# Altium schematic sheet EvvGC v1.3.SchDoc
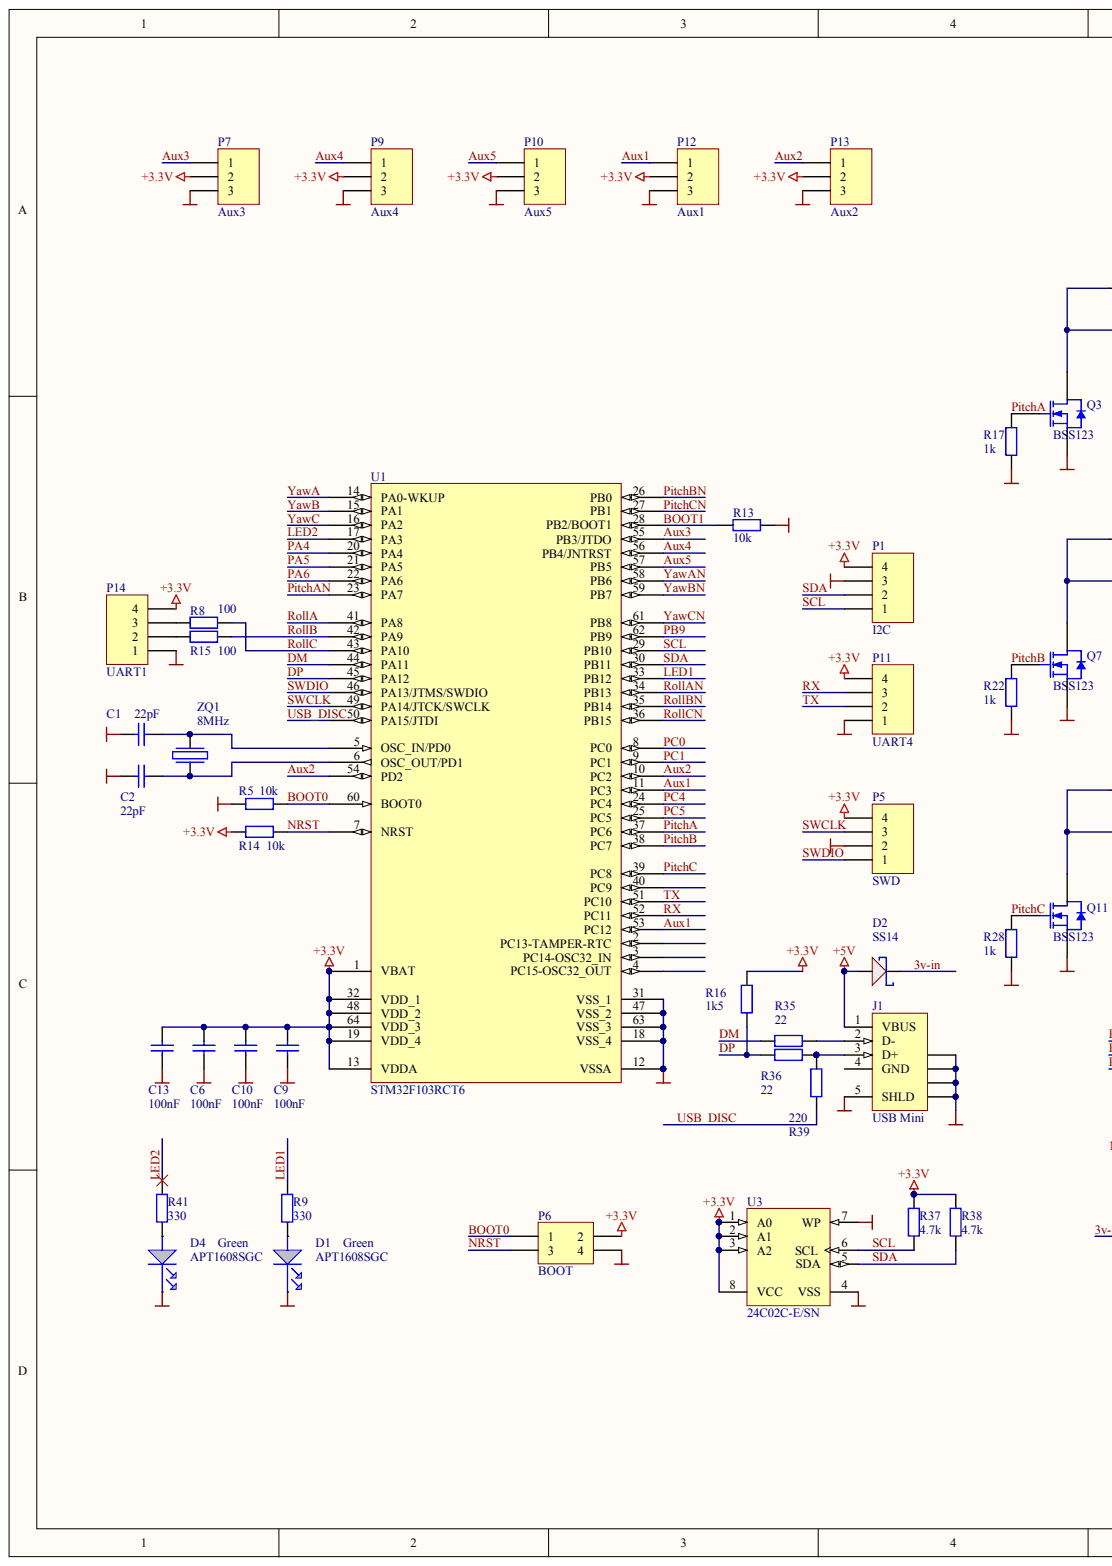
[diagram p0: EvvGC v1.3.SchDoc, left]
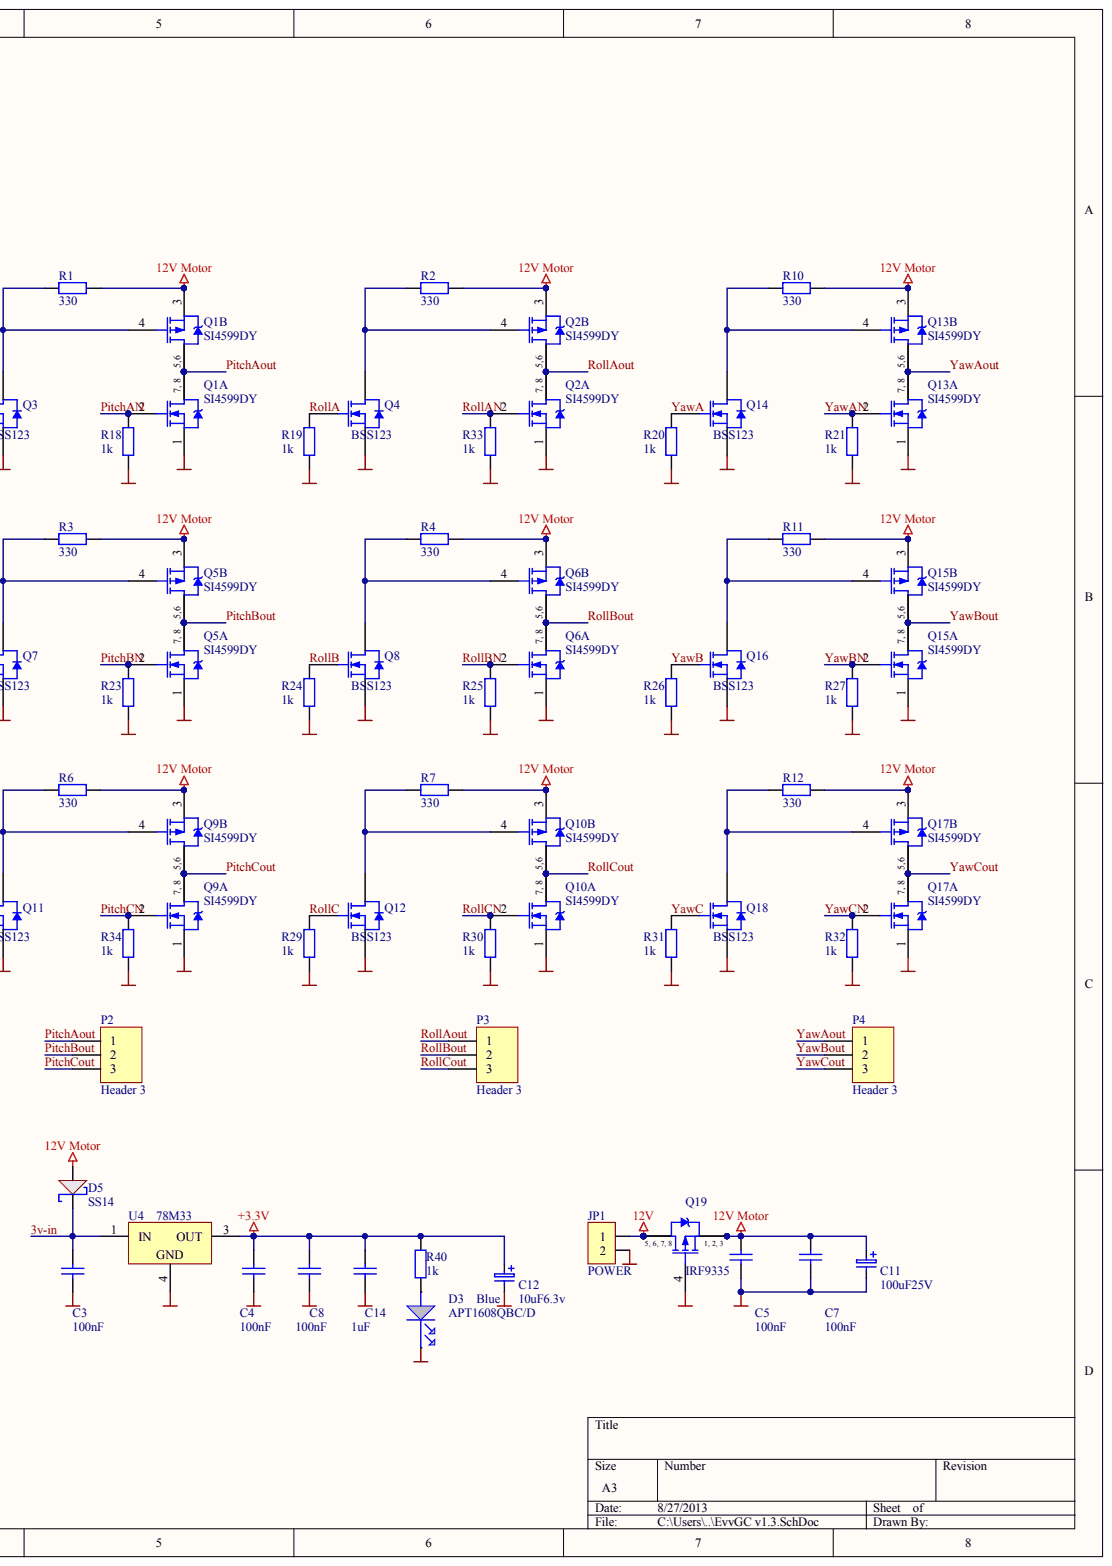
[diagram p1: EvvGC v1.3.SchDoc, right]

## Parts
C1  22pF
C2  22pF
C3  100nF
C4  100nF
C5  100nF
C6  100nF
C7  100nF
C8  100nF
C9  100nF
C10  100nF
C11  100uF25V
C12  10uF6.3v
C13  100nF
C14  1uF
D1  APT1608SGC  value Green
D2  SS14
D3  APT1608QBC/D  value Blue
D4  APT1608SGC  value Green
D5  SS14
J1  USB Mini
JP1  POWER
P1  I2C
P2  Header 3
P3  Header 3
P4  Header 3
P5  SWD
P6  BOOT
P7  Aux3
P9  Aux4
P10  Aux5
P11  UART4
P12  Aux1
P13  Aux2
P14  UART1
Q1  SI4599DY  (parts A,B)
Q2  SI4599DY  (parts A,B)
Q3  BSS123
Q4  BSS123
Q5  SI4599DY  (parts A,B)
Q6  SI4599DY  (parts A,B)
Q7  BSS123
Q8  BSS123
Q9  SI4599DY  (parts A,B)
Q10  SI4599DY  (parts A,B)
Q11  BSS123
Q12  BSS123
Q13  SI4599DY  (parts A,B)
Q14  BSS123
Q15  SI4599DY  (parts A,B)
Q16  BSS123
Q17  SI4599DY  (parts A,B)
Q18  BSS123
Q19  IRF9335
R1  330
R2  330
R3  330
R4  330
R5  10k
R6  330
R7  330
R8  100
R9  330
R10  330
R11  330
R12  330
R13  10k
R14  10k
R15  100
R16  1k5
R17  1k
R18  1k
R19  1k
R20  1k
R21  1k
R22  1k
R23  1k
R24  1k
R25  1k
R26  1k
R27  1k
R28  1k
R29  1k
R30  1k
R31  1k
R32  1k
R33  1k
R34  1k
R35  22
R36  22
R37  4.7k
R38  4.7k
R39  220
R40  1k
R41  330
U1  STM32F103RCT6
U3  24C02C-E/SN
U4  78M33
ZQ1  8MHz

## Nets
12V: JP1.1 Q19.5 Q19.6 Q19.7 Q19.8
12V MOTOR: C5.1 C7.1 C11.1 D5.A Q1.3 Q2.3 Q5.3 Q6.3 Q9.3 Q10.3 Q13.3 Q15.3 Q17.3 Q19.1 Q19.2 Q19.3 R1.2 R2.2 R3.2 R4.2 R6.2 R7.2 R10.2 R11.2 R12.2
+3.3V: C4.1 C6.1 C8.1 C9.1 C10.1 C12.1 C13.1 C14.1 P1.4 P5.4 P6.2 P7.2 P9.2 P10.2 P11.4 P12.2 P13.2 P14.4 R14.2 R16.1 R37.2 R38.2 R40.1 U1.1(VBAT) U1.13(VDDA) U1.19(VDD_4) U1.32(VDD_1) U1.48(VDD_2) U1.64(VDD_3) U3.1(A0) U3.2(A1) U3.3(A2) U3.8(VCC) U4.3
+5V: D2.A J1.1(VBUS)
GND: C1.2 C2.2 C3.2 C4.2 C5.2 C6.2 C7.2 C8.2 C9.2 C10.2 C11.2 C12.2 C13.2 C14.2 D1.K D3.K D4.K J1.5(SHLD) J1.6 J1.7 J1.8 J1.9 JP1.2 P1.3 P5.2 P6.4 P7.3 P9.3 P10.3 P11.1 P12.3 P13.3 P14.1 Q1.1 Q2.1 Q3.2 Q4.2 Q5.1 Q6.1 Q7.2 Q8.2 Q9.1 Q10.1 Q11.2 Q12.2 Q13.1 Q14.2 Q15.1 Q16.2 Q17.1 Q18.2 Q19.4 R5.2 R13.1 R17.1 R18.1 R19.1 R20.1 R21.1 R22.1 R23.1 R24.1 R25.1 R26.1 R27.1 R28.1 R29.1 R30.1 R31.1 R32.1 R33.1 R34.1 U1.12(VSSA) U1.18(VSS_4) U1.31(VSS_1) U1.47(VSS_2) U1.63(VSS_3) U3.4(VSS) U3.7(WP) U4.4
3V-IN: C3.1 D2.K D5.K U4.1
AUX1: P12.1 U1.11(PC3) U1.53(PC12)
AUX2: P13.1 U1.10(PC2) U1.54(PD2)
AUX3: P7.1 U1.55(PB3/JTDO)
AUX4: P9.1 U1.56(PB4/JNTRST)
AUX5: P10.1 U1.57(PB5)
BOOT0: P6.1 R5.1 U1.60(BOOT0)
BOOT1: R13.2 U1.28(PB2/BOOT1)
DM: R35.1 U1.44(PA11)
DP: R16.2 R36.1 U1.45(PA12)
LED1: R9.1 U1.33(PB12)
LED2: R41.1 U1.17(PA3)
NRST: P6.3 R14.1 U1.7(NRST)
PA4: U1.20(PA4)
PA5: U1.21(PA5)
PA6: U1.22(PA6)
PB9: U1.62(PB9)
PC0: U1.8(PC0)
PC1: U1.9(PC1)
PC4: U1.24(PC4)
PC5: U1.25(PC5)
PITCHA: Q3.1 R17.2 U1.37(PC6)
PITCHAN: Q1.2 R18.2 U1.23(PA7)
PITCHAOUT: P2.1 Q1.5 Q1.6 Q1.7 Q1.8
PITCHB: Q7.1 R22.2 U1.38(PC7)
PITCHBN: Q5.2 R23.2 U1.26(PB0)
PITCHBOUT: P2.2 Q5.5 Q5.6 Q5.7 Q5.8
PITCHC: Q11.1 R28.2 U1.39(PC8)
PITCHCN: Q9.2 R34.2 U1.27(PB1)
PITCHCOUT: P2.3 Q9.5 Q9.6 Q9.7 Q9.8
ROLLA: Q4.1 R19.2 U1.41(PA8)
ROLLAN: Q2.2 R33.2 U1.34(PB13)
ROLLAOUT: P3.1 Q2.5 Q2.6 Q2.7 Q2.8
ROLLB: Q8.1 R15.1 R24.2 U1.42(PA9)
ROLLBN: Q6.2 R25.2 U1.35(PB14)
ROLLBOUT: P3.2 Q6.5 Q6.6 Q6.7 Q6.8
ROLLC: Q12.1 R8.1 R29.2 U1.43(PA10)
ROLLCN: Q10.2 R30.2 U1.36(PB15)
ROLLCOUT: P3.3 Q10.5 Q10.6 Q10.7 Q10.8
RX: P11.3 U1.52(PC11)
SCL: P1.1 R37.1 U1.29(PB10) U3.6(SCL)
SDA: P1.2 R38.1 U1.30(PB11) U3.5(SDA)
SWCLK: P5.3 U1.49(PA14/JTCK/SWCLK)
SWDIO: P5.1 U1.46(PA13/JTMS/SWDIO)
TX: P11.2 U1.51(PC10)
USB_DISC: R39.1 U1.50(PA15/JTDI)
YAWA: Q14.1 R20.2 U1.14(PA0-WKUP)
YAWAN: Q13.2 R21.2 U1.58(PB6)
YAWAOUT: P4.1 Q13.5 Q13.6 Q13.7 Q13.8
YAWB: Q16.1 R26.2 U1.15(PA1)
YAWBN: Q15.2 R27.2 U1.59(PB7)
YAWBOUT: P4.2 Q15.5 Q15.6 Q15.7 Q15.8
YAWC: Q18.1 R31.2 U1.16(PA2)
YAWCN: Q17.2 R32.2 U1.61(PB8)
YAWCOUT: P4.3 Q17.5 Q17.6 Q17.7 Q17.8
NetC1_1: C1.1 U1.5(OSC_IN/PD0) ZQ1.1
NetC2_1: C2.1 U1.6(OSC_OUT/PD1) ZQ1.2
NetD1_A: D1.A R9.2
NetD3_A: D3.A R40.2
NetD4_A: D4.A R41.2
NetJ1_2: J1.2(D-) R35.2
NetJ1_3: J1.3(D+) R36.2 R39.2
NetP14_2: P14.2 R15.2
NetP14_3: P14.3 R8.2
NetQ1_4: Q1.4 Q3.3 R1.1
NetQ2_4: Q2.4 Q4.3 R2.1
NetQ5_4: Q5.4 Q7.3 R3.1
NetQ6_4: Q6.4 Q8.3 R4.1
NetQ9_4: Q9.4 Q11.3 R6.1
NetQ10_4: Q10.4 Q12.3 R7.1
NetQ13_4: Q13.4 Q14.3 R10.1
NetQ15_4: Q15.4 Q16.3 R11.1
NetQ17_4: Q17.4 Q18.3 R12.1

Not connected: J1 4(GND); U1 2(PC13-TAMPER-RTC) 3(PC14-OSC32_IN) 4(PC15-OSC32_OUT) 40(PC9)

## Library data of the parts
C14 = (no symbol name): Value: 100nF; Published: 8-Jun-2000; LatestRevisionDate: 17-Jul-2002; LatestRevisionNote: Re-released for DXP Platform.; PackageReference: CAPC2012L; Publisher: Altium Limited
D3 = (no symbol name): Value: Blue
J1 = (no symbol name): Note: Directive 2000/53/EC (ELV) Compliant; ContactMaterial: Copper Alloy; ContactPlating: Gold (30); PCB_Layout: Complies with manufacturer's recommendation.; DrillSize: Corresponds to nominal value.; PanelGround: Without; Published: 15-Jul-2004; DatasheetDocument: 27-Jun-2003; PackageDocument: 27-Jun-2003; PackageReference: 440247; HousingMaterial: Thermoplastic; ComponentLink1Description: Manufacturer Link; ComponentLink1URL: http://www.tycoelectronics.com/; ComponentLink2Description: Packaging Specification; ComponentLink2URL: http://ecommas.tycoelectronics.com/commerce/DocumentDelivery/DDEController?Action=showdoc&DocId=Customer+Drawing%7F440247%7FD%7Fpdf%7FEnglish%7FC_440247_D.pdf; Publisher: Altium Limited
Q19 = (no symbol name): Manufacturer: International Rectifier; Part Number: IRF9335PBF; Published: 8-Jun-2000; LatestRevisionDate: 13-Apr-2006; LatestRevisionNote: IPC-7351 Footprint Added.; DatasheetVersion: Mar-1999; PackageVersion: Feb-2001; PackageReference: SO-8; PackageDescription: 8-Pin Plastic Small Outline Integrated Circuit 1.27 mm Pitch; Code_JEDEC: MS-012-AA; Code_IPC: SOIC127P600-8; ComponentLink1Description: Manufacturer Link; ComponentLink1URL: http://www.irf.com/; ComponentLink2Description: Datasheet; ComponentLink2URL: http://www.irf.com/product-info/datasheets/data/irf7404.pdf; Publisher: Altium Limited
R1-R4 R6 R7 R10-R12 R17-R34 R39 = (no symbol name): Value: 330R; Published: 8-Jun-2000; LatestRevisionDate: 17-Jul-2002; LatestRevisionNote: Re-released for DXP Platform.; PackageReference: AXIAL-0.4; PackageDescription: Axial Device, Thru-Hole; 2 Leads; 0.4 in Pin Spacing; Publisher: Altium Limited
R5 R8 R9 R13-R15 R41 = (no symbol name): Value: 1K; Power W: 0.1W; Tolerance: ±5%; Resistance (Ohms): 1000; Category: Rasistors; Package / Case: 0603 (1608 Metric); Price 1k (Eur): 0.00231
R16 R40 = (no symbol name): Power W: 0.1W; Tolerance: ±5%; Resistance (Ohms): 1000; Category: Rasistors; Package / Case: 0603 (1608 Metric); Price 1k (Eur): 0.00231
  R16: Value: 1K5
  R40: Value: 1K
R35-R38 = (no symbol name): Value: 1K5; Power W: 0.1W; Tolerance: ±5%; Resistance (Ohms): 1000; Category: Rasistors; Package / Case: 0603 (1608 Metric); Price 1k (Eur): 0.00231
C1-C13 D1 D2 D4 D5 JP1 P1-P7 P9-P14 Q1-Q18 U1 U3 U4 ZQ1 = (no symbol name)
  C1 C2: Published: 8-Jun-2000; LatestRevisionDate: 17-Jul-2002; LatestRevisionNote: Re-released for DXP Platform.; PackageReference: CAPC2012L; Value: 22pF
  C3-C10 C13: Published: 8-Jun-2000; LatestRevisionDate: 17-Jul-2002; LatestRevisionNote: Re-released for DXP Platform.; PackageReference: CAPC2012L; Value: 100nF
  P1-P7 P9-P14: LatestRevisionDate: 17-Jul-2002; LatestRevisionNote: Re-released for DXP Platform.
  Q1 Q2 Q5 Q6 Q9 Q10 Q13 Q15 Q17: Published: 8-Jun-2000; LatestRevisionDate: 13-Apr-2006; DatasheetVersion: Aug-1997; PackageVersion: Feb-2001; PackageReference: SO-8; PackageDescription: 8-Pin Plastic Small Outline Integrated Circuit 1.27 mm Pitch; Code_JEDEC: MS-012-AA; Code_IPC: SOIC127P600-8; ComponentLink1Description: Manufacturer Link; ComponentLink1URL: http://www.irf.com/; ComponentLink2Description: Datasheet
  Q3 Q4 Q7 Q8 Q11 Q12 Q14 Q16 Q18: Published: 17-Sep-2003; LatestRevisionDate: 12-Dec-2006; LatestRevisionNote: IPC-7351 Footprint Added.; DatasheetVersion: 27-Jul-2000; PackageVersion: May-1999; PackageReference: SOT23; PackageDescription: 3-Pin SOT-23 Package 0.95 mm Pitch, 2.3 mm Lead Span; ComponentLink1Description: Manufacturer Link; ComponentLink1URL: http://www.semiconductors.philips.com/; ComponentLink2Description: Datasheet; ComponentLink2URL: http://www.semiconductors.philips.com/acrobat/datasheets/2N7002_4.pdf
  U1: Published: 30-May-2008; DatasheetVersion: rev6, Mar-2008; PackageVersion: Mar-2008; PackageReference: LQFP64; PackageDescription: 64-Pin Low-Profile Quad Flat Pack, 10 x 10 mm Body, 0.5 mm Pitch (LQFP64); ComponentLink1Description: Manufacturer Link; ComponentLink1URL: www.st.com/stm32; ComponentLink2Description: Datasheet; ComponentLink2URL: http://www.st.com/stonline/products/literature/ds/13587.pdf
  U3: Published: 29-Jan-2009; DatasheetVersion: revJ, Aug-2008; PackageVersion: revAW, Oct-2008; PackageReference: SOIC-SN8; PackageDescription: 8-Lead Plastic Small Outline (SN) - Narrow, 3.90mm Body [SOIC]; Code_JEDEC: MS-012; Code_IPC: SOIC127P600-8; ComponentLink1Description: Manufacturer Link; ComponentLink1URL: http://www.microchip.com; ComponentLink2Description: Datasheet; ComponentLink2URL: http://ww1.microchip.com/downloads/en/DeviceDoc/21202J.pdf; ComponentLink3Description: Packaging Specification; ComponentLink3URL: http://ww1.microchip.com/downloads/en/PackagingSpec/00049AW.pdf
  U4: Published: 24-Aug-2001; LatestRevisionDate: 06-Jun-2005; LatestRevisionNote: PCB pads lengthened.; DatasheetVersion: Apr-1994; PackageVersion: Jun-2004; PackageReference: TO-252; PackageDescription: D-PAK/TO-252-AA; 3 Leads (1 Cropped); Body 10.1 (inc. leads) x 6.6 mm (LxW max); ComponentLink1Description: Manufacturer Link; ComponentLink1URL: http://www.st.com/; ComponentLink2Description: Datasheet; ComponentLink2URL: http://www.st.com/stonline/products/literature/ds/2146/l78m05c.pdf
  C1-C10 C13 P1-P7 P9-P14 Q1-Q18 U1 U3 U4: Publisher: Altium Limited
